# Altium schematic sheet FPGA.SchDoc(FP)
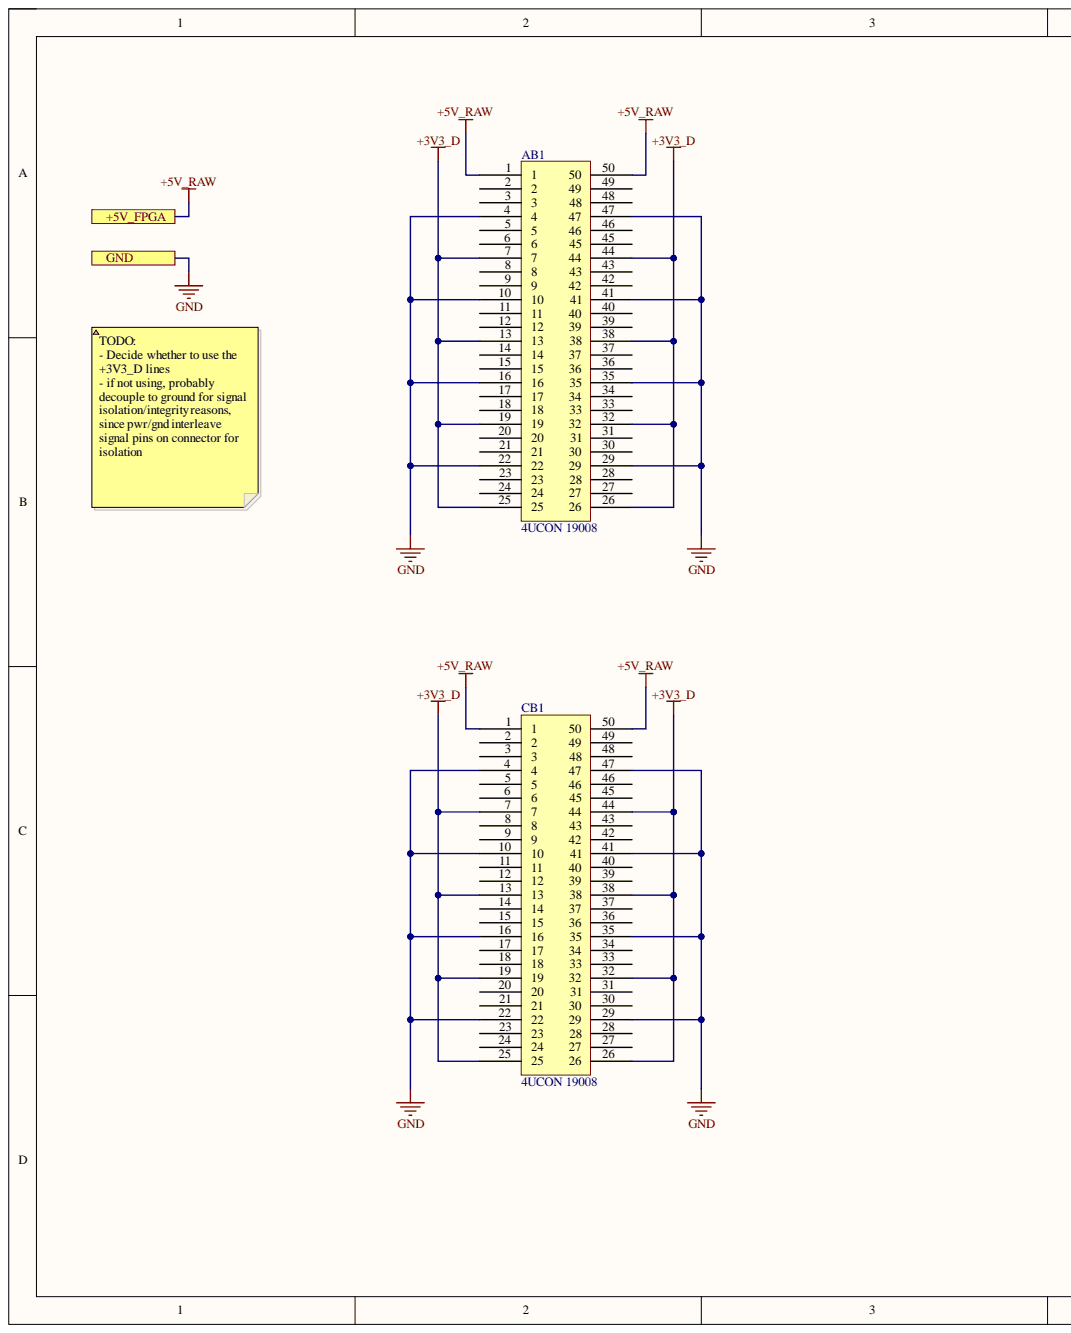
[diagram p0: FPGA.SchDoc, left]
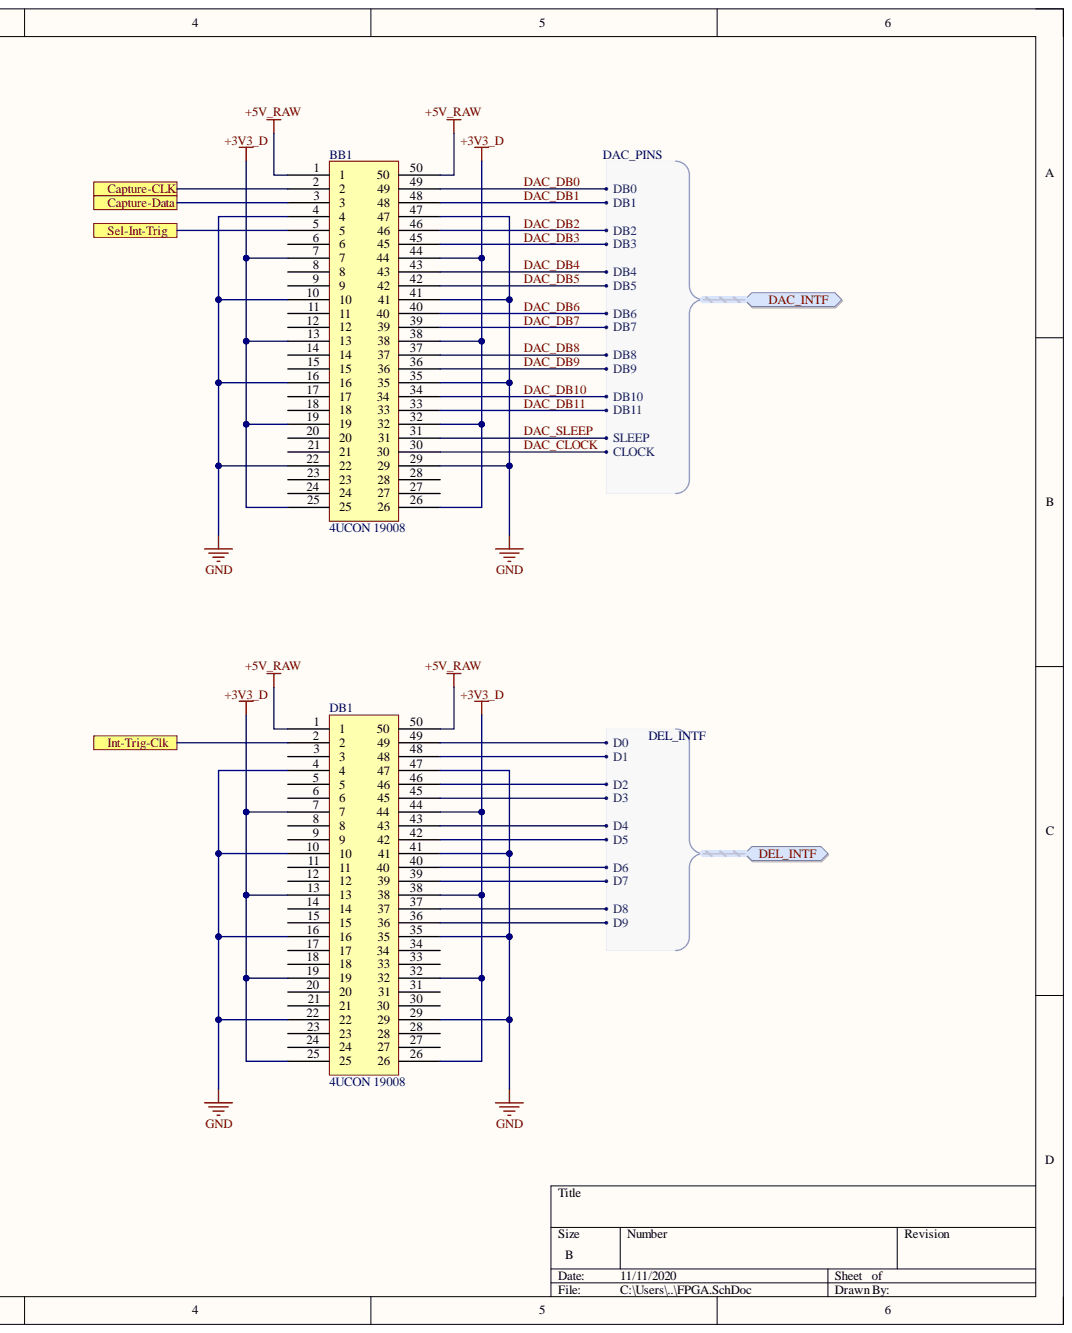
[diagram p1: FPGA.SchDoc, right]

## Parts
AB1  4UCON 19008  [4UCON_19008]
BB1  4UCON 19008  [4UCON_19008]
CB1  4UCON 19008  [4UCON_19008]
DB1  4UCON 19008  [4UCON_19008]

## Ports
+5V_FPGA, Capture-CLK, Capture-Data, DAC_INTF, DAC_INTF.CLOCK, DAC_INTF.DB0, DAC_INTF.DB1, DAC_INTF.DB2, DAC_INTF.DB3, DAC_INTF.DB4, DAC_INTF.DB5, DAC_INTF.DB6, DAC_INTF.DB7, DAC_INTF.DB8, DAC_INTF.DB9, DAC_INTF.DB10, DAC_INTF.DB11, DAC_INTF.SLEEP, DEL_INTF, DEL_INTF.D0, DEL_INTF.D1, DEL_INTF.D2, DEL_INTF.D3, DEL_INTF.D4, DEL_INTF.D5, DEL_INTF.D6, DEL_INTF.D7, DEL_INTF.D8, DEL_INTF.D9, GND, Int-Trig-Clk, Sel-Int-Trig

## Nets
+3V3_D: AB1.7 AB1.13 AB1.19 AB1.25 AB1.26 AB1.32 AB1.38 AB1.44 BB1.7 BB1.13 BB1.19 BB1.25 BB1.26 BB1.32 BB1.38 BB1.44 CB1.7 CB1.13 CB1.19 CB1.25 CB1.26 CB1.32 CB1.38 CB1.44 DB1.7 DB1.13 DB1.19 DB1.25 DB1.26 DB1.32 DB1.38 DB1.44
+5V_RAW: AB1.1 AB1.50 BB1.1 BB1.50 CB1.1 CB1.50 DB1.1 DB1.50 ; port +5V_FPGA
Capture-CLK: BB1.2 ; port Capture-CLK
Capture-Data: BB1.3 ; port Capture-Data
DAC_CLOCK: BB1.30 ; port DAC_INTF
DAC_DB0: BB1.49 ; port DAC_INTF
DAC_DB1: BB1.48 ; port DAC_INTF
DAC_DB2: BB1.46 ; port DAC_INTF
DAC_DB3: BB1.45 ; port DAC_INTF
DAC_DB4: BB1.43 ; port DAC_INTF
DAC_DB5: BB1.42 ; port DAC_INTF
DAC_DB6: BB1.40 ; port DAC_INTF
DAC_DB7: BB1.39 ; port DAC_INTF
DAC_DB8: BB1.37 ; port DAC_INTF
DAC_DB9: BB1.36 ; port DAC_INTF
DAC_DB10: BB1.34 ; port DAC_INTF
DAC_DB11: BB1.33 ; port DAC_INTF
DAC_SLEEP: BB1.31 ; port DAC_INTF
GND: AB1.4 AB1.10 AB1.16 AB1.22 AB1.29 AB1.35 AB1.41 AB1.47 BB1.4 BB1.10 BB1.16 BB1.22 BB1.29 BB1.35 BB1.41 BB1.47 CB1.4 CB1.10 CB1.16 CB1.22 CB1.29 CB1.35 CB1.41 CB1.47 DB1.4 DB1.10 DB1.16 DB1.22 DB1.29 DB1.35 DB1.41 DB1.47 ; port GND
Int-Trig-Clk: DB1.2 ; port Int-Trig-Clk
Sel-Int-Trig: BB1.5 ; port Sel-Int-Trig
NetAB1_2: AB1.2
NetAB1_3: AB1.3
NetAB1_5: AB1.5
NetAB1_6: AB1.6
NetAB1_8: AB1.8
NetAB1_9: AB1.9
NetAB1_11: AB1.11
NetAB1_12: AB1.12
NetAB1_14: AB1.14
NetAB1_15: AB1.15
NetAB1_17: AB1.17
NetAB1_18: AB1.18
NetAB1_20: AB1.20
NetAB1_21: AB1.21
NetAB1_23: AB1.23
NetAB1_24: AB1.24
NetAB1_27: AB1.27
NetAB1_28: AB1.28
NetAB1_30: AB1.30
NetAB1_31: AB1.31
NetAB1_33: AB1.33
NetAB1_34: AB1.34
NetAB1_36: AB1.36
NetAB1_37: AB1.37
NetAB1_39: AB1.39
NetAB1_40: AB1.40
NetAB1_42: AB1.42
NetAB1_43: AB1.43
NetAB1_45: AB1.45
NetAB1_46: AB1.46
NetAB1_48: AB1.48
NetAB1_49: AB1.49
NetBB1_6: BB1.6
NetBB1_8: BB1.8
NetBB1_9: BB1.9
NetBB1_11: BB1.11
NetBB1_12: BB1.12
NetBB1_14: BB1.14
NetBB1_15: BB1.15
NetBB1_17: BB1.17
NetBB1_18: BB1.18
NetBB1_20: BB1.20
NetBB1_21: BB1.21
NetBB1_23: BB1.23
NetBB1_24: BB1.24
NetBB1_27: BB1.27
NetBB1_28: BB1.28
NetCB1_2: CB1.2
NetCB1_3: CB1.3
NetCB1_5: CB1.5
NetCB1_6: CB1.6
NetCB1_8: CB1.8
NetCB1_9: CB1.9
NetCB1_11: CB1.11
NetCB1_12: CB1.12
NetCB1_14: CB1.14
NetCB1_15: CB1.15
NetCB1_17: CB1.17
NetCB1_18: CB1.18
NetCB1_20: CB1.20
NetCB1_21: CB1.21
NetCB1_23: CB1.23
NetCB1_24: CB1.24
NetCB1_27: CB1.27
NetCB1_28: CB1.28
NetCB1_30: CB1.30
NetCB1_31: CB1.31
NetCB1_33: CB1.33
NetCB1_34: CB1.34
NetCB1_36: CB1.36
NetCB1_37: CB1.37
NetCB1_39: CB1.39
NetCB1_40: CB1.40
NetCB1_42: CB1.42
NetCB1_43: CB1.43
NetCB1_45: CB1.45
NetCB1_46: CB1.46
NetCB1_48: CB1.48
NetCB1_49: CB1.49
NetDB1_3: DB1.3
NetDB1_5: DB1.5
NetDB1_6: DB1.6
NetDB1_8: DB1.8
NetDB1_9: DB1.9
NetDB1_11: DB1.11
NetDB1_12: DB1.12
NetDB1_14: DB1.14
NetDB1_15: DB1.15
NetDB1_17: DB1.17
NetDB1_18: DB1.18
NetDB1_20: DB1.20
NetDB1_21: DB1.21
NetDB1_23: DB1.23
NetDB1_24: DB1.24
NetDB1_27: DB1.27
NetDB1_28: DB1.28
NetDB1_30: DB1.30
NetDB1_31: DB1.31
NetDB1_33: DB1.33
NetDB1_34: DB1.34
NetDB1_36: DB1.36 ; port DEL_INTF
NetDB1_37: DB1.37 ; port DEL_INTF
NetDB1_39: DB1.39 ; port DEL_INTF
NetDB1_40: DB1.40 ; port DEL_INTF
NetDB1_42: DB1.42 ; port DEL_INTF
NetDB1_43: DB1.43 ; port DEL_INTF
NetDB1_45: DB1.45 ; port DEL_INTF
NetDB1_46: DB1.46 ; port DEL_INTF
NetDB1_48: DB1.48 ; port DEL_INTF
NetDB1_49: DB1.49 ; port DEL_INTF

## Library data of the parts
AB1 BB1 CB1 DB1 = 4UCON 19008: Component Kind: Standard; Library Name: Local_Parts.SchLib
